# Altium schematic sheet analog.SchDoc(analog)
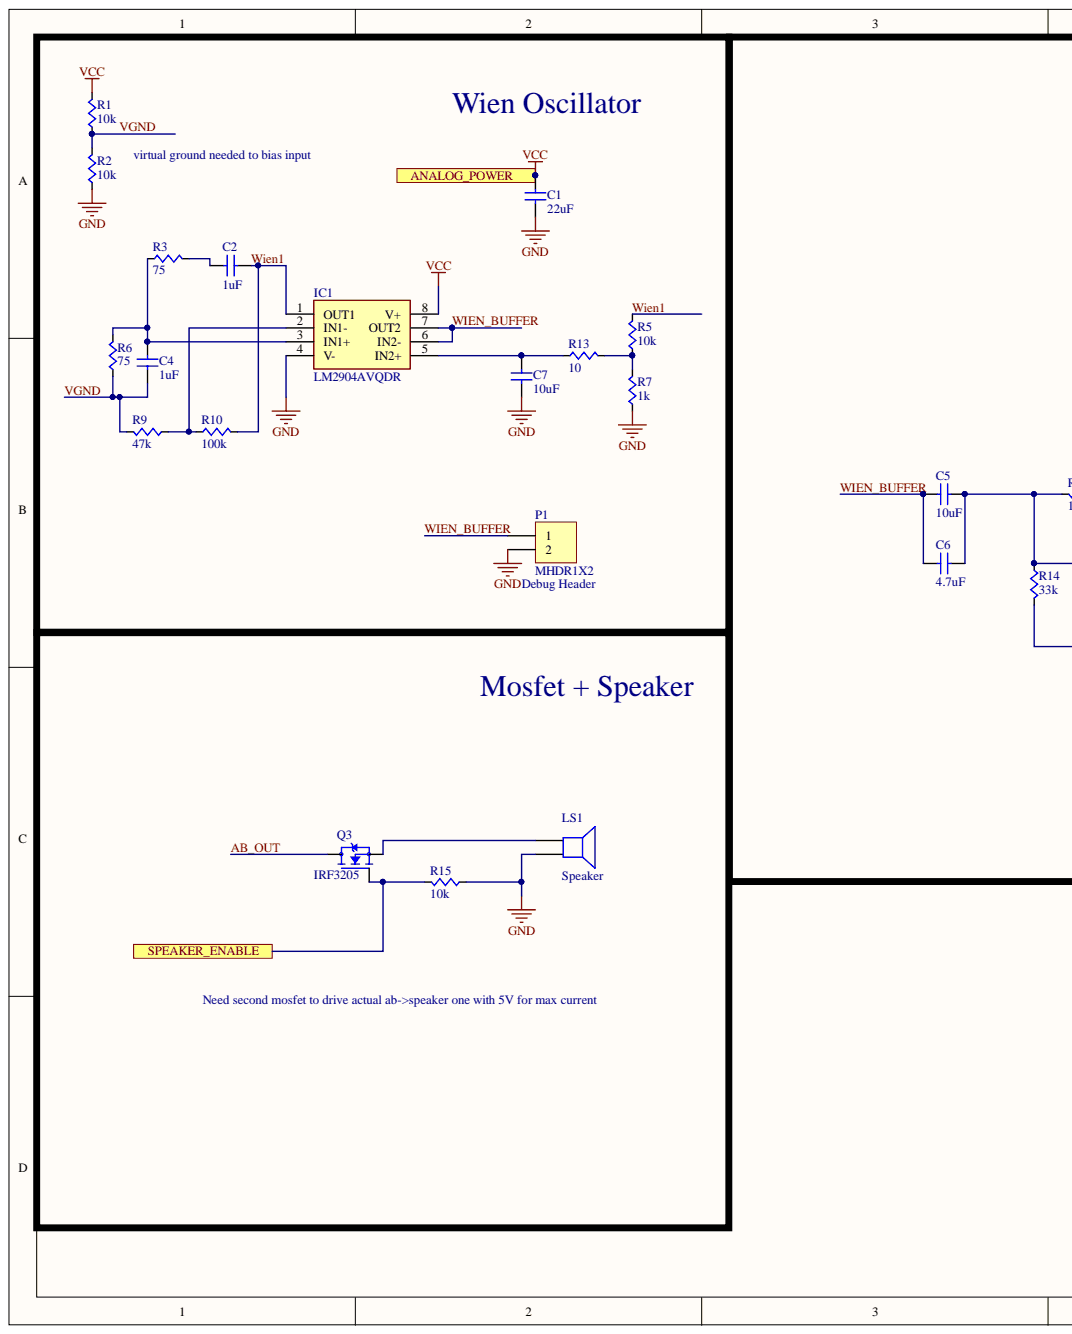
[diagram p0: analog.SchDoc, left]
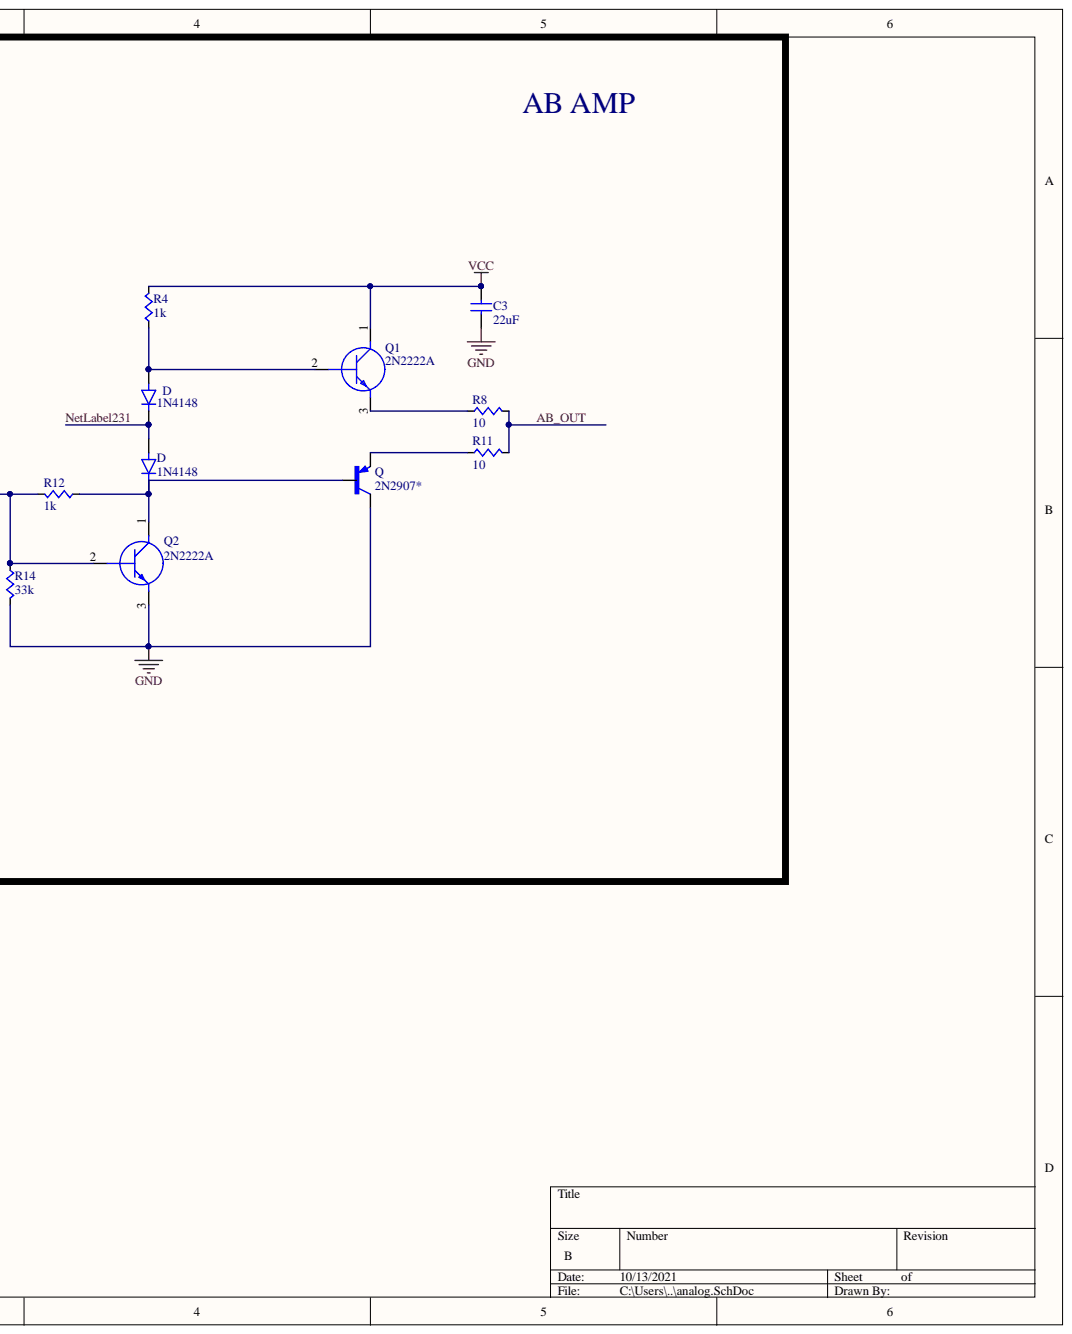
[diagram p1: analog.SchDoc, right]

## Parts
C1  value 22uF  [CAPC0603]  (hidden comment: Cap)
C2  value 1uF  [CAPC0603]  (hidden comment: Cap)
C3  value 22uF  [CAPC0603]  (hidden comment: Cap)
C4  value 1uF  [CAPC0603]  (hidden comment: Cap)
C5  value 10uF  [CAPC0603]  (hidden comment: Cap)
C6  value 4.7uF  [CAPC0603]  (hidden comment: Cap)
C7  value 10uF  [CAPC0603]  (hidden comment: Cap)
D  1N4148  [DIOAD829W49L456D191]
D  1N4148  [DIOAD829W49L456D191]
IC1  LM2904AVQDR  [SOIC127P600X175-8N]
LS1  Speaker  [PIN2]
P1  MHDR1X2  [MHDR1X2]
Q  2N2907*  [TO92-EBC-OVAL]
Q1  2N2222A  [2N2222A]
Q2  2N2222A  [2N2222A]
Q3  IRF3205  [TO254P1041X444X2933-3]
R1  value 10k  [RES0603]  (hidden comment: Res)
R2  value 10k  [RES0603]  (hidden comment: Res)
R3  value 75  [RES0603]  (hidden comment: Res)
R4  value 1k  [RES0603]  (hidden comment: Res)
R5  value 10k  [RES0603]  (hidden comment: Res)
R6  value 75  [RES0603]  (hidden comment: Res)
R7  value 1k  [RES0603]  (hidden comment: Res)
R8  value 10  [RES0603]  (hidden comment: Res)
R9  value 47k  [RES0603]  (hidden comment: Res)
R10  value 100k  [RES0603]  (hidden comment: Res)
R11  value 10  [RES0603]  (hidden comment: Res)
R12  value 1k  [RES0603]  (hidden comment: Res)
R13  value 10  [RES0603]  (hidden comment: Res)
R14  value 33k  [RES0603]  (hidden comment: Res)
R15  value 10k  [RES0603]  (hidden comment: Res)

## Ports
ANALOG_POWER, SPEAKER_ENABLE

## Nets
GND: C1.1 C3.2 C7.2 IC1.4(V-) LS1.2 P1.2 Q.C Q2.3 R2.2 R7.2 R14.1 R15.2
AB_OUT: Q3.2 R8.1 R11.1
NetLabel231: D.A D.C
SPEAKER_ENABLE: Q3.1 R15.1 ; port SPEAKER_ENABLE
VCC: C1.2 C3.1 IC1.8(V+) Q1.1 R1.1 R4.1 ; port ANALOG_POWER
VGND: C4.2 R1.2 R2.1 R6.2 R9.1
Wien1: C2.2 IC1.1(OUT1) R5.1 R10.1
WIEN_BUFFER: C5.1 C6.1 IC1.6(IN2-) IC1.7(OUT2) P1.1
NetC2_1: C2.1 R3.1
NetC4_1: C4.1 IC1.3(IN1+) R3.2 R6.1
NetC5_2: C5.2 C6.2 Q2.2 R12.1 R14.2
NetC7_1: C7.1 IC1.5(IN2+) R13.2
NetD_A: D.A Q1.2 R4.2
NetD_C: D.C Q.B Q2.1 R12.2
NetIC1_2: IC1.2(IN1-) R9.2 R10.2
NetLS1_1: LS1.1 Q3.3
NetQ1_3: Q1.3 R8.2
NetQ_E: Q.E R11.2
NetR5_2: R5.2 R7.1 R13.1

## Library data of the parts
D D = 1N4148: DIODE FAST SIGNAL 500MA/100V; MF: MICROSS/On Semiconductor; SnapEDA_Link: https://www.snapeda.com/parts/1N4148/MICROSS/On%20Semiconductor/view-part/9246/?ref=snap; Price: None; Package: DO-35 Fairchild Semiconductor; MP: 1N4148; Library Name: 1N4148.IntLib; Component Kind: Standard; Availability: Unavailable
IC1 = LM2904AVQDR: Integrated Circuit; Manufacturer_Name: Texas Instruments; Mouser Price/Stock: https://www.mouser.co.uk/ProductDetail/Texas-Instruments/LM2904AVQDR?qs=VBduBm9rCJQa5LBobI0Nyg%3D%3D; Mouser Part Number: 595-LM2904AVQDR; Manufacturer_Part_Number: LM2904AVQDR; Height: 1.75mm; Datasheet Link: http://www.ti.com/lit/gpn/lm2904v; Component Kind: Standard; Arrow Price/Stock: https://www.arrow.com/en/products/lm2904avqdr/texas-instruments; Arrow Part Number: LM2904AVQDR
LS1 = Speaker: Loudspeaker; Published: 24-Mar-1999; PackageReference: PIN2; Library Name: Miscellaneous Devices.IntLib; LatestRevisionNote: Re-released for DXP Platform.; LatestRevisionDate: 17-Jul-2002; Component Kind: Standard; Publisher: Altium Limited
P1 = MHDR1X2: Header, 2-Pin; Signal Integrity: Connector; Library Name: Miscellaneous Connectors.IntLib; LatestRevisionNote: Re-released for DXP Platform.; LatestRevisionDate: 17-Jul-2002; Component Kind: Standard; Publisher: Altium Limited
Q = 2N2907*: Bipolar _BJT_ Transistor PNP 40V 600mA 200MHz 1.8W Through Hole TO-18; MP: 2N2907; MF: ON Semiconductor; SnapEDA_Link: https://www.snapeda.com/parts/2N2907/ON%20Semiconductor/view-part/13809/?ref=snap; Price: None; Package: TO-18 STMicroelectronics; Library Name: 2N2907_.IntLib; Component Kind: Standard; Availability: Unavailable
Q1 Q2 = 2N2222A: Transistor BJT NPN; Manufacturer_Name: Foshan Blue Rocket Elec; Manufacturer_Part_Number: 2N2222A; Library Name: SamacSys.SchLib; Height: 4.7mm; Datasheet Link: https://datasheet.lcsc.com/szlcsc/1901291437_Foshan-Blue-Rocket-Elec-2N2222A_C358533.pdf; Component Kind: Standard
Q3 = IRF3205: N-Channel 55V 110A (Tc) 200W (Tc) Through Hole TO-262; MF: Infineon Technologies; SnapEDA_Link: https://www.snapeda.com/parts/IRF3205/Infineon%20Technologies/view-part/2889486/?ref=snap; Price: None; Package: D2PAK Infineon Technologies; MP: IRF3205; Library Name: IRF3205.IntLib; Component Kind: Standard; Availability: Unavailable
C1-C7 = Cap: Supplier Part Number 1: 1276-1000-1-ND; Supplier 1: Digi-Key; Library Name: Passives.SchLib; Component Kind: Standard
R1-R15 = Res: Supplier Part Number 1: RMCF0603JT10K0CT-ND; Supplier 1: Digi-Key; Library Name: Passives.SchLib; Component Kind: Standard
